# Altium schematic sheet new power management.SchDoc("new power management")
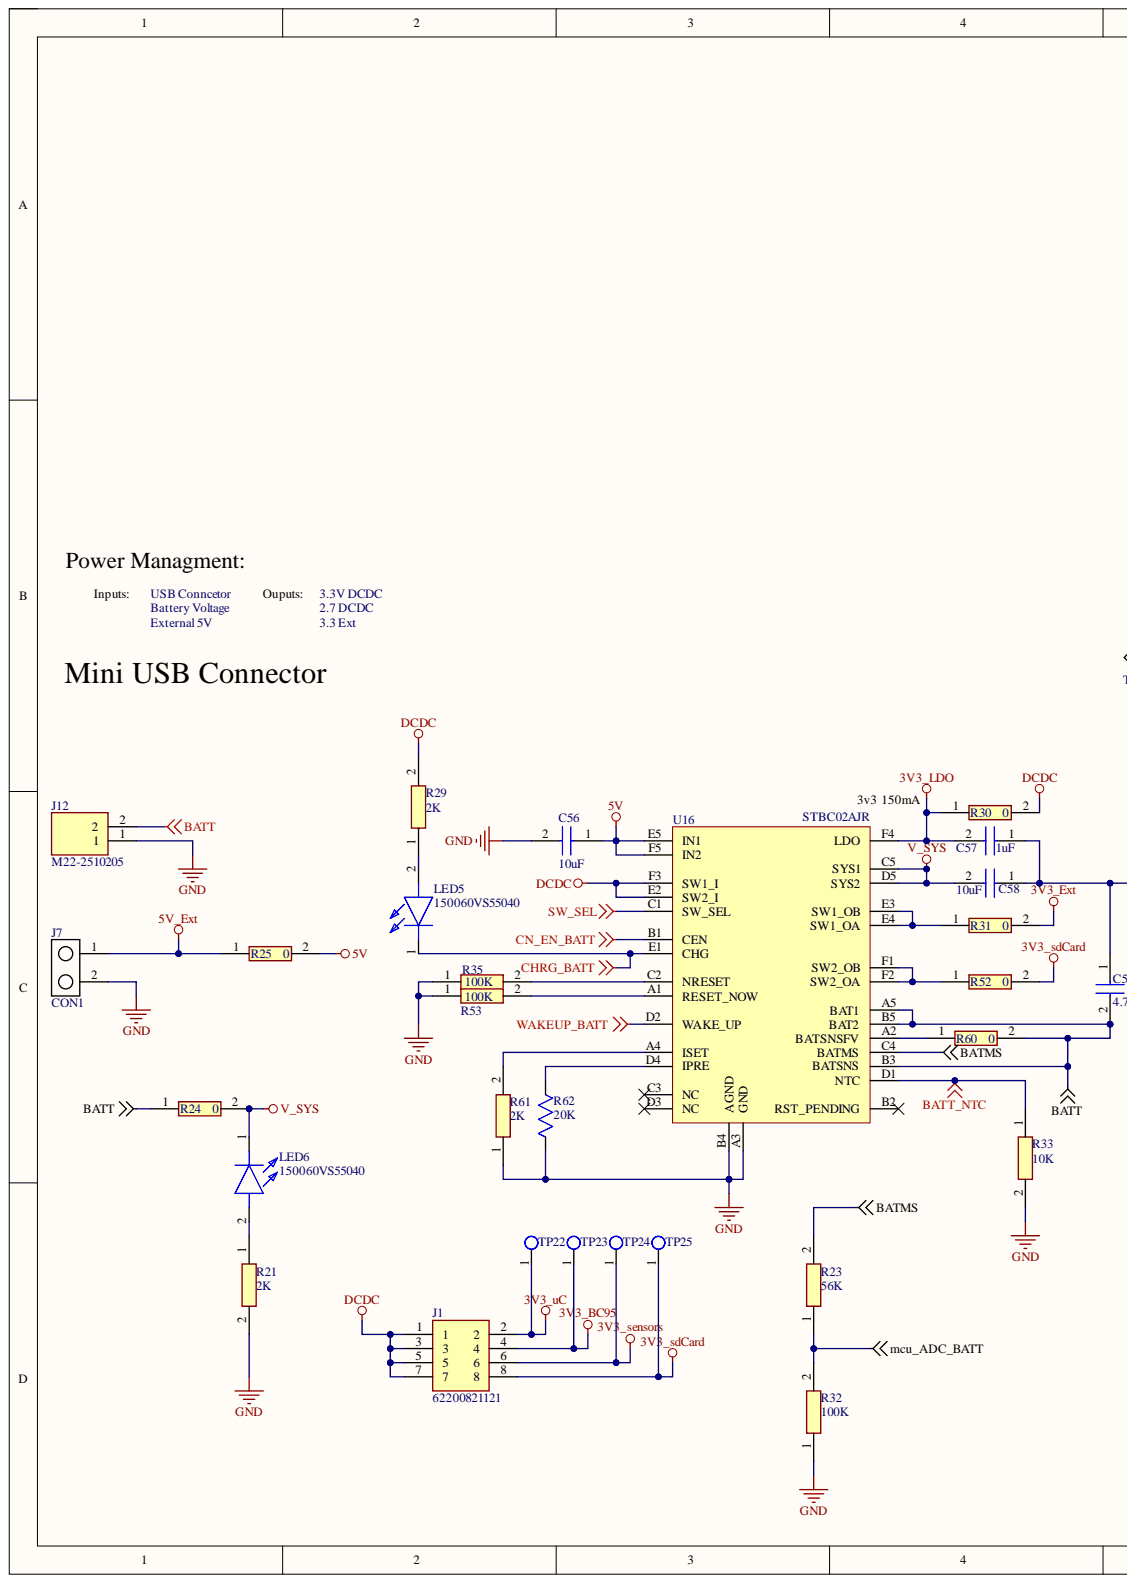
[diagram p0: new power management.SchDoc, left]
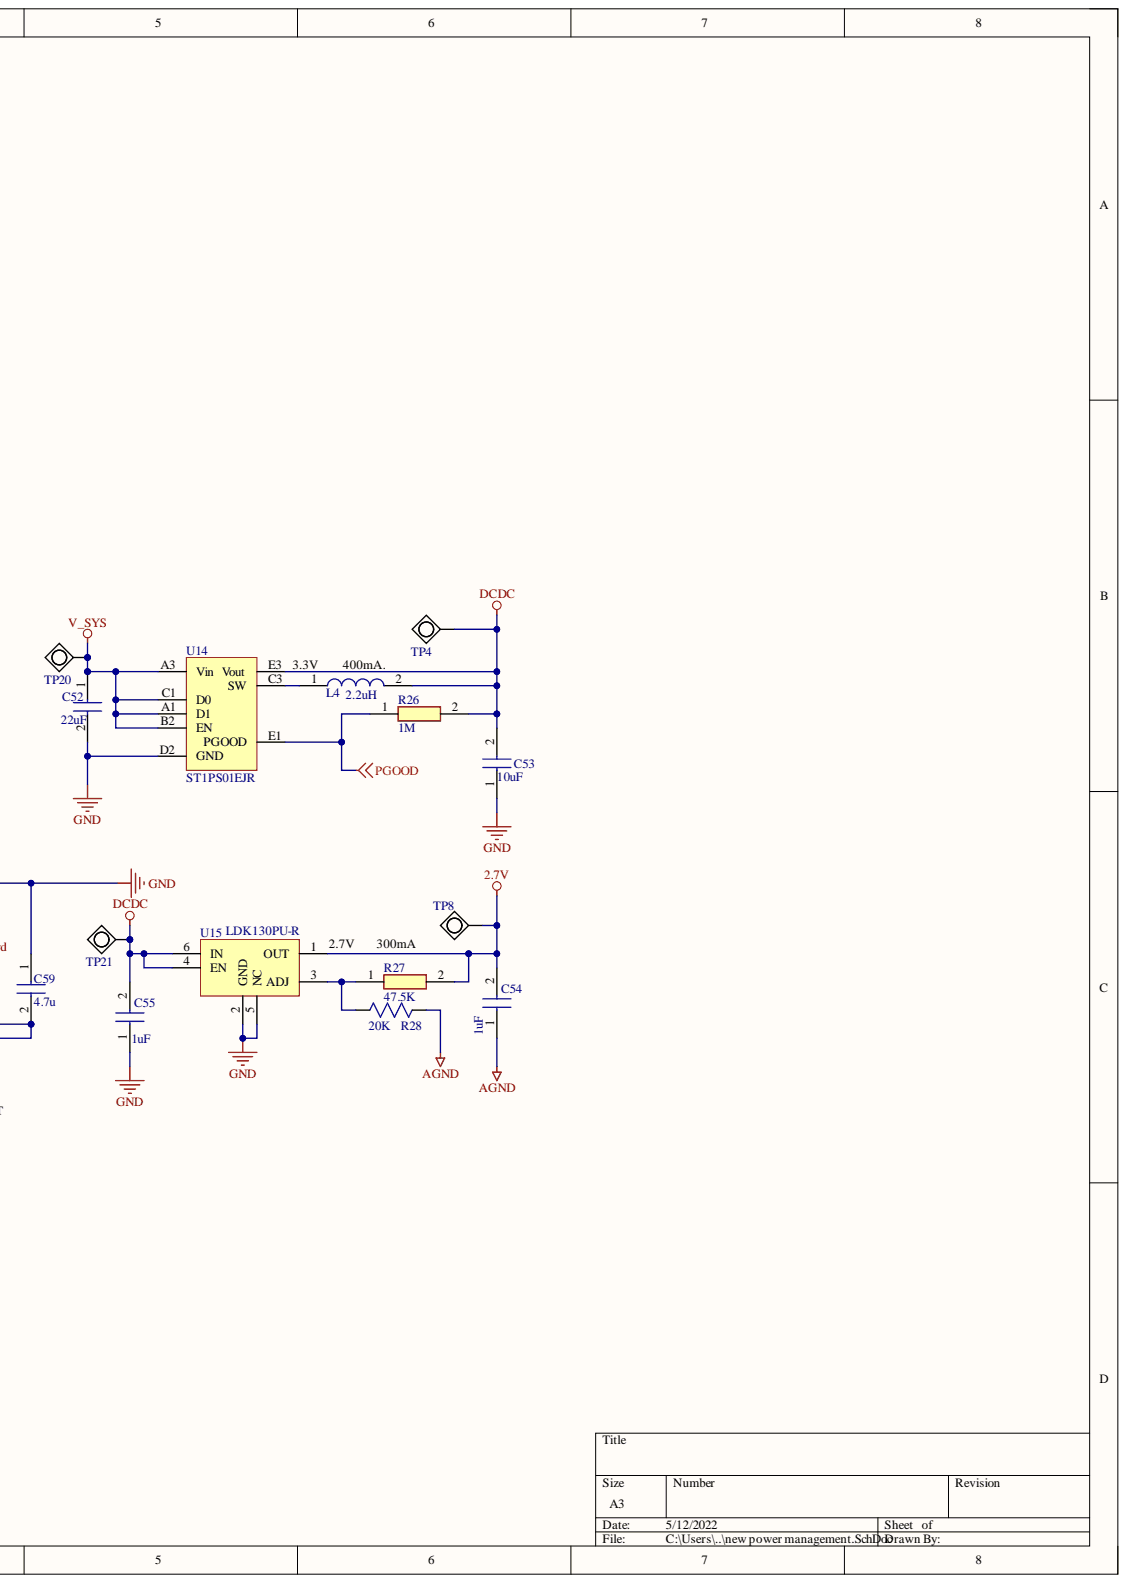
[diagram p1: new power management.SchDoc, right]

## Parts
C52  22uF  [CAPC1608X90N]
C53  10uF  [CAPC1005X90N]
C54  1uF  [CAPC1005X55N]
C55  1uF  [CAPC1005X55N]
C56  10uF  [CAPC1005X90N]
C57  1uF  [CAPC1005X55N]
C58  10uF  [CAPC1005X90N]
C59  4.7u  [CAPC3216X100N]
J1  62200821121  [HDRV8W50P127_2X4_508X340X530P]
J7  CON1  [1725656]
J12  M22-2510205  [HDRV2W60P0X200_1X2_400X200X525P]
L4  2.2uH  [INDC2520X100N]
LED5  150060VS55040  [LEDM168X50N]
LED6  150060VS55040  [LEDM168X50N]
R21  2K  [RESC1005X35N]
R23  56K  [ERA3KV_(0603)]
R24  0  [RESC1608X55N]
R25  0  [RESC1608X55N]
R26  1M  [RESC1005X40N]
R27  47.5K  [RESC1005X40N]
R28  20K  [RESC1005X40N]
R29  2K  [RESC1005X35N]
R30  0  [RESC1608X55N]
R31  0  [RESC1608X55N]
R32  100K  [RESC1608X55N]
R33  10K  [ERJ3EK_(0603)]
R35  100K  [RESC1005X40N]
R52  0  [RESC1608X55N]
R53  100K  [RESC1005X40N]
R60  0  [RESC1608X55N]
R61  2K  [RESC1005X35N]
R62  20K  [RESC1005X40N]
TP4  Test Point  [KEYSTONE_5001]  (value not drawn)
TP8  Test Point  [KEYSTONE_5001]  (value not drawn)
TP20  Test Point  [KEYSTONE_5001]  (value not drawn)
TP21  Test Point  [KEYSTONE_5001]  (value not drawn)
TP22  test_point  [test_point]  (value not drawn)  (no BOM)
TP23  test_point  [test_point]  (value not drawn)  (no BOM)
TP24  test_point  [test_point]  (value not drawn)  (no BOM)
TP25  test_point  [test_point]  (value not drawn)  (no BOM)
U14  ST1PS01EJR  Min Output Voltage: 3.3V  [ST1PS01EJR]
U15  LDK130PU-R  [LDK130PU18R]
U16  STBC02AJR  [BGA30C40P5X6_225X259X60]

## Nets
2.7V: C54.2 R27.2 TP8.1 U15.1(OUT)
3V3_BC95: J1.4 TP23.1
3V3_Ext: R31.2
3V3_LDO: C57.2 R30.1 U16.F4(LDO)
3V3_sdCard: J1.8 R52.2 TP25.1
3V3_sensors: J1.6 TP24.1
3V3_uC: J1.2 TP22.1
5V: C56.1 R25.2 U16.E5(IN1) U16.F5(IN2)
5V_Ext: J7.1 R25.1
AGND: C54.1 R28.1
BATMS: R23.2 U16.C4(BATMS)
BATT: C59.2 J12.2 R24.1 R60.2 U16.A5(BAT1) U16.B3(BATSNS) U16.B5(BAT2)
BATT_NTC: R33.1 U16.D1(NTC)
CHRG_BATT: LED5.1 U16.E1(CHG)
CN_EN_BATT: U16.B1(CEN)
DCDC: C53.2 C55.2 J1.1 J1.3 J1.5 J1.7 L4.2 R26.2 R29.2 R30.2 TP4.1 TP21.1 U14.E3(Vout) U15.4 U15.6(IN) U16.E2(SW2_I) U16.F3(SW1_I)
GND: C52.2 C53.1 C55.1 C56.2 C57.1 C58.1 C59.1 J7.2 J12.1 R21.2 R32.1 R33.2 R35.1 R53.1 R61.1 R62.2 U14.D2(GND) U15.2(GND) U15.5(NC) U16.A3(GND) U16.B4(AGND)
mcu_ADC_BATT: R23.1 R32.2
PGOOD: R26.1 U14.E1(PGOOD)
SW_SEL: U16.C1(SW_SEL)
V_SYS: C52.1 C58.2 LED6.1 R24.2 TP20.1 U14.A1(D1) U14.A3(Vin) U14.B2(EN) U14.C1(D0) U16.C5(SYS1) U16.D5(SYS2)
WAKEUP_BATT: U16.D2(WAKE_UP)
NetL4_1: L4.1 U14.C3(SW)
NetLED5_2: LED5.2 R29.1
NetLED6_2: LED6.2 R21.1
NetR27_1: R27.1 R28.2 U15.3(ADJ)
NetR31_1: R31.1 U16.E3(SW1_OB) U16.E4(SW1_OA)
NetR35_2: R35.2 U16.C2(NRESET)
NetR52_1: R52.1 U16.F1(SW2_OB) U16.F2(SW2_OA)
NetR53_2: R53.2 U16.A1(RESET_NOW)
NetR60_1: R60.1 U16.A2(BATSNSFV)
NetR61_2: R61.2 U16.A4(ISET)
NetR62_1: R62.1 U16.D4(IPRE)

Not connected: U16 B2(RST_PENDING) C3(NC) D3(NC)

## Library data of the parts
C52 = LMK107BBJ226MA-T: Capacitor; Manufacturer_Name: TAIYO YUDEN; Component Kind: Standard; Datasheet Link: https://componentsearchengine.com/Datasheets/1/LMK107BBJ226MA-T.pdf; Height: 0.9mm; Manufacturer_Part_Number: LMK107BBJ226MA-T
C53 C56 C58 = CL05A106MP8NUB8: Capacitor; Manufacturer_Name: Samsung Electro-Mechanics; Component Kind: Standard; Datasheet Link: https://datasheet.datasheetarchive.com/originals/distributors/DKDS-19/372904.pdf; Height: 0.9mm; Manufacturer_Part_Number: CL05A106MP8NUB8
C54 C55 C57 = JMK105BJ105KV-F: Capacitor; Manufacturer_Name: TAIYO YUDEN; Component Kind: Standard; Datasheet Link: https://datasheet.datasheetarchive.com/originals/distributors/Datasheets-DGA20/25674.pdf; Height: 0.55mm; Library Name: SamacSys.SchLib; Manufacturer_Part_Number: JMK105BJ105KV-F
C59 = C1206C224J1RACAUTO: Capacitor; Manufacturer_Name: Kemet; Component Kind: Standard; Datasheet Link: https://connect.kemet.com:7667/gateway/IntelliData-ComponentDocumentation/1.0/download/specsheet/C1206C224J1RACAUTO; Height: 1mm; Manufacturer_Part_Number: C1206C224J1RACAUTO
J1 = 62200821121: Connector; Manufacturer_Name: Wurth Elektronik; Component Kind: Standard; Height: 5.3mm; Manufacturer_Part_Number: 62200821121
J7 = 1725656: 间距2.54mm 2pin 6A 160V  螺钉式 直插 配线26-20AWG(0.14-0.5)mm²; Manufacturer: Phoenix Contact; Approvals: UL,CSA,cULus,EAC; Connector Type: Screw,PCB,Terminal Block; Max Supply Voltage: 63V; Number of Circuits: 2; Number of Levels: 1; Pitch: 2.54mm; Stripping Length: 4.5mm; Voltage: 125V; Wire Gauge (Max): 26AWG; Wire Gauge (Min): 20AWG; Category: Terminal Blocks; Color: Green; Component Kind: Standard; ComponentLink1Description: https://datasheet.ciiva.com/16071/1725656-16071846.pdf?src-supplier=Onlinecomponents.com; ComponentLink1URL: https://datasheet.ciiva.com/16071/1725656-16071846.pdf?src-supplier=Onlinecomponents.com; ComponentLink2Description: https://datasheet.ciiva.com/15169/getdatasheetpartid-199323-15169849.pdf?src-supplier=Verical; ComponentLink2URL: https://datasheet.ciiva.com/15169/getdatasheetpartid-199323-15169849.pdf?src-supplier=Verical; ComponentLink3Description: https://datasheet.ciiva.com/823/1725656-823793.pdf?src-supplier=Digi-Key; ComponentLink3URL: https://datasheet.ciiva.com/823/1725656-823793.pdf?src-supplier=Digi-Key; ComponentLink4Description: https://datasheet.ciiva.com/1120/319957-1120510.pdf?src-supplier=Farnell; ComponentLink4URL: https://datasheet.ciiva.com/1120/319957-1120510.pdf?src-supplier=Farnell; ComponentLink5Description: https://datasheet.ciiva.com/26340/788052-da-01-en-100ntklemme-1725656-250-st-26340907.pdf?src-supplier=Conrad; ComponentLink5URL: https://datasheet.ciiva.com/26340/788052-da-01-en-100ntklemme-1725656-250-st-26340907.pdf?src-supplier=Conrad; Contact Material: Tin; Current: 6A; Current Rating: 6A; Depth: 6.2mm; Flammability Rating: UL94 V-0; Height: 6.2mm; Housing Color: Green; Housing Material: Polyamide; Insulation Material: Nylon; Lead Free: Lead Free; Length: 5.54mm; Manufacturer Part Number: 1725656; Max Current Rating: 6A; Mount: Through Hole; Number of Contacts: 2; Number of Pins: 2; Number of Poles: 2; Number of Positions: 2; Number of Positions Per Level: 2; Number of Rows: 1; Orientation: Straight; Package Quantity: 50; Packaging: Bulk; Radiation Hardening: No; REACH SVHC: No SVHC; RoHS: Yes; RoHS Compliant: Yes; Series: MPT; Stock 1: 7470; Supplier 1: RSComponents; Supplier Part Number 1: 2204260; Termination: Solder; Voltage Rating: 125V; Weight: 0.022575oz; Width: 12mm; Wire/Cable Gauge: 26AWG; Library Name: shm_schem_lib.SchLib
J12 = M22-2510205: Connector; Manufacturer_Name: Harwin; Component Kind: Standard; Datasheet Link: https://cdn.harwin.com/pdfs/M22-251.pdf; Height: 5.25mm; Manufacturer_Part_Number: M22-2510205
L4 = 74438323022: Inductor; Manufacturer_Name: Wurth Elektronik; Component Kind: Standard; Datasheet Link: https://componentsearchengine.com//74438323022.pdf; Height: 1mm; Manufacturer_Part_Number: 74438323022; Mouser2 Part Number: 710-74438323022; Mouser2 Price/Stock: https://www.mouser.com/Search/Refine.aspx?Keyword=710-74438323022
LED5 LED6 = 150060VS55040: LED; Manufacturer_Name: Wurth Elektronik; Component Kind: Standard; Datasheet Link: https://katalog.we-online.com/led/datasheet/150060VS55040.pdf; Height: 0.5mm; Manufacturer_Part_Number: 150060VS55040
R21 R29 R61 = RT0402FRE072KL: Resistor; Manufacturer_Name: YAGEO (PHYCOMP); Component Kind: Standard; Datasheet Link: https://datasheet.datasheetarchive.com/originals/distributors/Datasheets_SAMA/a1f8f48f216d234b3e6a96d43a77a0c1.pdf; Height: 0.35mm; Manufacturer_Part_Number: RT0402FRE072KL
R23 = ERA-3VRW5602V: Resistor; Manufacturer_Name: Panasonic; Component Kind: Standard; Datasheet Link: http://industrial.panasonic.com/cdbs/www-data/pdf/RDM0000/RDM0000C331.pdf; Height: 0.4mm; Manufacturer_Part_Number: ERA-3VRW5602V
R24 R25 R30 R31 R52 R60 = RC0603FR-070RL: Resistor; Manufacturer_Name: YAGEO (PHYCOMP); Component Kind: Standard; Height: 0.55mm; Manufacturer_Part_Number: RC0603FR-070RL
R26 = CRG0402F1M0: Resistor; Manufacturer_Name: TE Connectivity; Component Kind: Standard; Datasheet Link: https://datasheet.datasheetarchive.com/originals/distributors/DKDS-21/401698.pdf; Height: 0.4mm; Manufacturer_Part_Number: CRG0402F1M0
R27 = RC0402FR-0747K5L: Resistor; Manufacturer_Name: YAGEO (PHYCOMP); Component Kind: Standard; Datasheet Link: https://componentsearchengine.com//RC0402FR-0747K5L.pdf; Height: 0.4mm; Manufacturer_Part_Number: RC0402FR-0747K5L; Mouser2 Part Number: 603-RC0402FR-0747K5L; Mouser2 Price/Stock: https://www.mouser.com/Search/Refine.aspx?Keyword=603-RC0402FR-0747K5L
R28 R62 = RC0402FR-0720KL: 20 kOhms Â±1% 0.063W, 1/16W Chip Resistor 0402 _1005 Metric_ Moisture Resistant Thick Film; MF: Yageo; Availability: Unavailable; Component Kind: Standard; Library Name: SnapEDAsch.SchLib; MP: RC0402FR-0720KL; Package: 0402 Yageo; Price: None; SnapEDA_Link: https://www.snapeda.com/parts/RC0402FR-0720KL/Yageo/view-part/614868/?ref=snap
R32 = CHV0603AFX-1003ELF: Resistor; Manufacturer_Name: Bourns; Component Kind: Standard; Datasheet Link: https://www.bourns.com/docs/product-datasheets/chv.pdf?sfvrsn=adcf1bf9_27; Height: 0.55mm; Manufacturer_Part_Number: CHV0603AFX-1003ELF
R33 = ERJ-3EKF1002V: Resistor; Manufacturer_Name: Panasonic; Component Kind: Standard; Datasheet Link: https://componentsearchengine.com//ERJ-3EKF1002V.pdf; Height: 0.55mm; Manufacturer_Part_Number: ERJ-3EKF1002V; Mouser2 Part Number: 667-ERJ-3EKF1002V; Mouser2 Price/Stock: https://www.mouser.com/Search/Refine.aspx?Keyword=667-ERJ-3EKF1002V
R35 R53 = CRG0402F100K: Resistor; Manufacturer_Name: TE Connectivity; Component Kind: Standard; Datasheet Link: https://datasheet.datasheetarchive.com/originals/distributors/DKDS-21/401698.pdf; Height: 0.4mm; Manufacturer_Part_Number: CRG0402F100K
TP4 TP8 TP20 TP21 = 5001: TEST POINT PC MINI .040D BLACK; Manufacturer: Keystone Electronics; Body Material: Bronze; Hole Diameter: 1.6mm; Inside Diameter: 1.27mm; Insulation: Insulated; Number of Contacts: 1; Outside Diameter: 2.54mm; Plating: Silver; Category: Test Probes, Leads and Clips; Color: Black; Component Kind: Standard; ComponentLink1Description: https://datasheet.ciiva.com/27052/1581986-27052611.pdf?src-supplier=Jameco; ComponentLink1URL: https://datasheet.ciiva.com/27052/1581986-27052611.pdf?src-supplier=Jameco; ComponentLink2Description: https://datasheet.ciiva.com/11836/0900766b8009b7c7-11836045.pdf?src-supplier=RSComponents; ComponentLink2URL: https://datasheet.ciiva.com/11836/0900766b8009b7c7-11836045.pdf?src-supplier=RSComponents; ComponentLink3Description: https://datasheet.ciiva.com/16318/m60-2p60-16318423.pdf?src-supplier=Future+Electronics; ComponentLink3URL: https://datasheet.ciiva.com/16318/m60-2p60-16318423.pdf?src-supplier=Future+Electronics; ComponentLink4Description: https://datasheet.ciiva.com/22631/1703983-22631100.pdf?src-supplier=Element14; ComponentLink4URL: https://datasheet.ciiva.com/22631/1703983-22631100.pdf?src-supplier=Element14; Contact Material: Bronze; Contact Plating: Silver,Tin; Diameter: 2.54mm; Flammability Rating: UL94 V-0; Height: 0.3inch; Housing Color: Black; Insulation Material: Nylon; Lead Free: Lead Free; Length: 7.62mm; Manufacturer Part Number: 5001; Material: Nylon,Bronze,Silver; Mount: Through Hole; Number of Ports: 1; Orientation: Straight; Package Quantity: 100; Packaging: Bulk; REACH SVHC: No SVHC; RoHS: Yes; RoHS Compliant: Yes; Series: THM; Stock 1: 647250; Supplier 1: Digi-Key; Supplier Part Number 1: 36-5001-ND; Termination: Through Hole; Library Name: shm_schem_lib.SchLib
U14 = ST1PS01EJR: 400mA Nano-Quiescent Synchronous step-down converter with digital voltage select; Manufacturer: STMicroelectronics; Max Input Voltage: 5.5V; Min Input Voltage: 1.8V; Number of Outputs: 1; Output Configuration: Positive; Output Type: Fixed; Switching Frequency: 2MHz; Synchronous Rectifier: Yes; Category: Voltage Regulators - Switching; Component Kind: Standard; ComponentLink1Description: https://www.st.com/resource/en/datasheet/st1ps01.pdf?src-supplier=Digi-Key; ComponentLink1URL: https://www.st.com/resource/en/datasheet/st1ps01.pdf?src-supplier=Digi-Key; Manufacturer Part Number: ST1PS01EJR; Max Operating Temperature: 85°C; Min Operating Temperature: -40°C; Mount: Surface Mount; Output Current: 400mA; Packaging: Tape and Reel; RoHS: Yes; RoHS Compliant: Yes; Stock 1: 500; Supplier 1: RSComponents; Supplier Part Number 1: 1924839; Library Name: shm_schem_lib.SchLib
U15 = LDK130PU-R: Adjustable Positive LDO Regulator, 0.8V Min, 5.1V Max, 0.4V Dropout, PDSO6; Manufacturer: STMicroelectronics; Accuracy: 2%; Dropout Voltage: 200mV; Max Input Voltage: 5.5V; Max Output Current: 300mA; Min Input Voltage: 1.9V; Min Output Voltage: 800mV; Number of Outputs: 1; Operating Supply Current: 70uA; Output Voltage: 5.3V; Power Supply Rejection Ratio (PSRR): 55dB; Quiescent Current: 60uA; Case/Package: DFN; Category: Voltage Regulators - Linear; Component Kind: Standard; ComponentLink1Description: https://datasheet.ciiva.com/30007/dm00076097-30007047.pdf?src-supplier=Avnet; ComponentLink1URL: https://datasheet.ciiva.com/30007/dm00076097-30007047.pdf?src-supplier=Avnet; ComponentLink2Description: https://datasheet.ciiva.com/29568/dm00076097-29568344.pdf?src-supplier=Digi-Key; ComponentLink2URL: https://datasheet.ciiva.com/29568/dm00076097-29568344.pdf?src-supplier=Digi-Key; ComponentLink3Description: https://datasheet.ciiva.com/29568/dm00076097-29568345.pdf?src-supplier=Digi-Key; ComponentLink3URL: https://datasheet.ciiva.com/29568/dm00076097-29568345.pdf?src-supplier=Digi-Key; ComponentLink4Description: https://datasheet.ciiva.com/29568/dm00076097-29568346.pdf?src-supplier=Digi-Key; ComponentLink4URL: https://datasheet.ciiva.com/29568/dm00076097-29568346.pdf?src-supplier=Digi-Key; Height: 0.45mm; Lead Free: Lead Free; Length: 1.3mm; Manufacturer Part Number: LDK130PU-R; Max Operating Temperature: 125°C; Min Operating Temperature: -40°C; Mount: Surface Mount; Number of Pins: 6; Number of Regulators: 1; Output Current: 300mA; Output Type: Adjustable; Output Voltage Accuracy: 2%; Package Quantity: 3000; Packaging: Tape and Reel; Polarity: Positive; Radiation Hardening: No; REACH SVHC: No SVHC; RoHS: Yes; RoHS Compliant: Yes; Stock 1: 232; Supplier 1: Mouser; Supplier Part Number 1: 511-LDK130PU-R; Tolerance: 2%; Width: 1.2mm; Library Name: shm_schem_lib.SchLib
U16 = STBC02AJR: Li-Ion linear battery charger with LDO, load switches and reset generator; Manufacturer: STMicroelectronics; Max Charge Current: 450mA; Number of Cells: 1; Category: Power Management ICs; Component Kind: Standard; ComponentLink1Description: http://www.st.com/content/ccc/resource/technical/document/datasheet/group3/af/ef/64/cd/00/0f/41/1a/DM00289033/files/DM00289033.pdf/jcr:content/translations/en.DM00289033.pdf?src-supplier=Digi-Key; ComponentLink1URL: http://www.st.com/content/ccc/resource/technical/document/datasheet/group3/af/ef/64/cd/00/0f/41/1a/DM00289033/files/DM00289033.pdf/jcr:content/translations/en.DM00289033.pdf?src-supplier=Digi-Key; ComponentLink2Description: http://www.farnell.com/datasheets/2145097.pdf?src-supplier=Element14; ComponentLink2URL: http://www.farnell.com/datasheets/2145097.pdf?src-supplier=Element14; Manufacturer Part Number: STBC02AJR; Max Operating Temperature: 85°C; Min Operating Temperature: -40°C; Number of Pins: 30; Packaging: Cut Tape; REACH SVHC: No SVHC; RoHS: Yes; RoHS Compliant: Yes; Stock 1: 2944; Supplier 1: Digi-Key; Supplier Part Number 1: 497-16561-1-ND; Library Name: shm_schem_lib.SchLib
TP22-TP25 = test_point: Library Name: shm_schem_lib.SchLib
